# Altium schematic sheet [06] - BUZZER.SchDoc
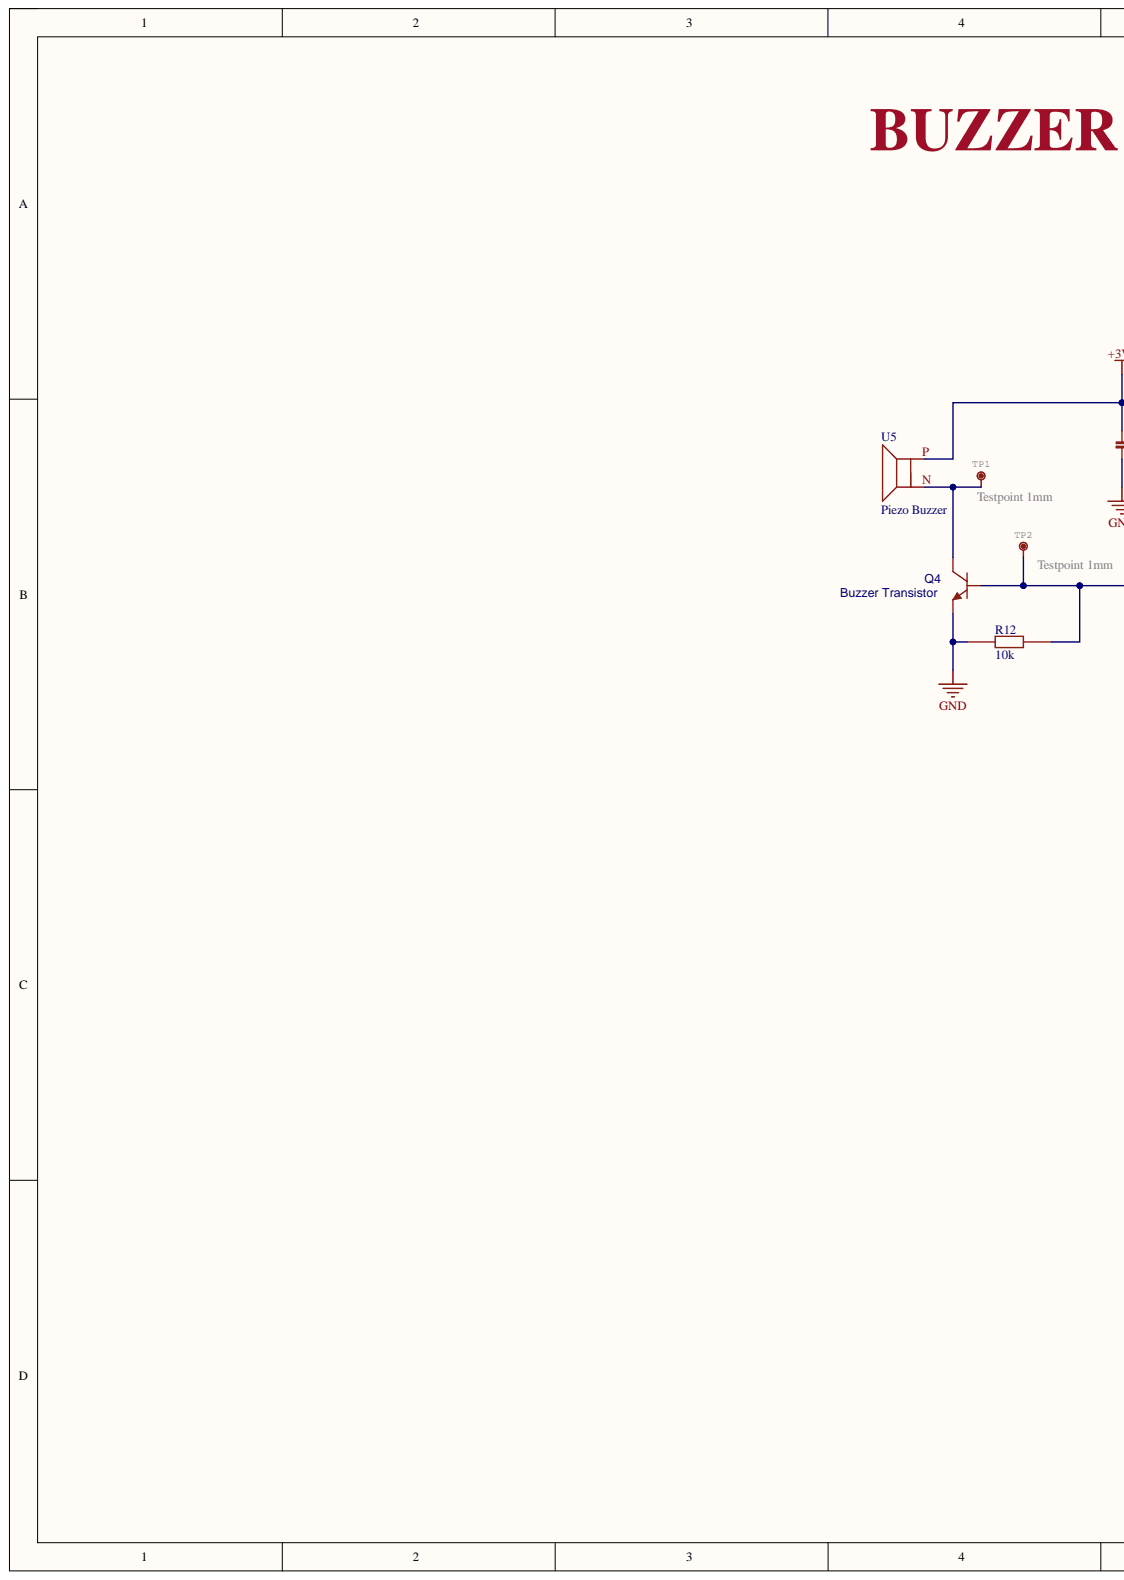
[diagram p0: [06] - BUZZER.SchDoc, left]
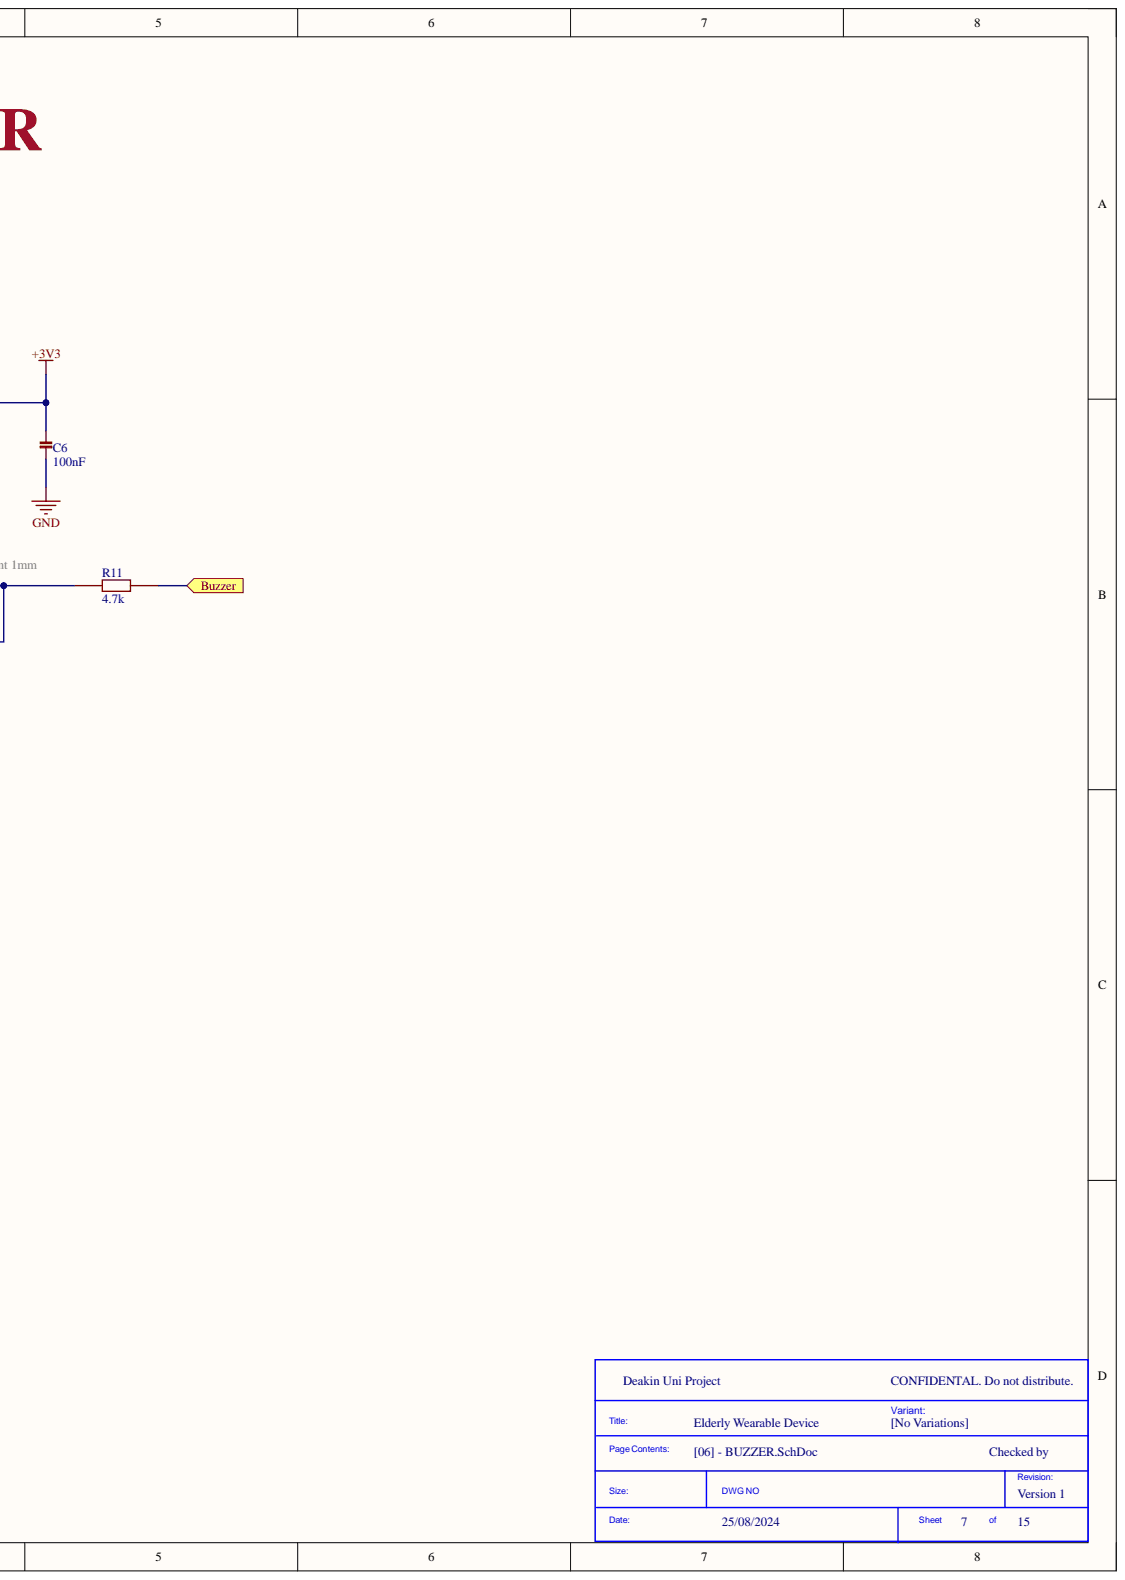
[diagram p1: [06] - BUZZER.SchDoc, right]

## Parts
C6  100nF  [C_0402]
Q4  Buzzer Transistor  [Buzzer_Transistor]
R11  4.7k  [R_0603]
R12  10k  [R_0603]
TP1  Testpoint 1mm  [Testpoint_1mm]
TP2  Testpoint 1mm  [Testpoint_1mm]
U5  Piezo Buzzer  [PIEZO_BUZZER]

## Ports
Buzzer

## Nets
+3V3: C6.2 U5.P
GND: C6.1 Q4.2 R12.2
Buzzer: R11.2 ; port Buzzer
NetQ4_1: Q4.1 R11.1 R12.1 TP2.X
NetQ4_3: Q4.3 TP1.X U5.N

## Library data of the parts
C6 = C_0402_100nF: SMD Capacitor; Manufacturer: Murata Electronics; Manufacturer Part Number: GRM155R71C104KA88J; ALL GOOD: OK_DONE; Component Kind: Standard; Package: 0402; Supplier: Digi-Key; Supplier Part Number: 490-6328-1-ND; Library Name: Elderly_Wearable_Device.SCHLIB
Q4 = Buzzer Transistor: Transistor, NPN, 40V, 0.2A, SOT-23; Manufacturer: onsemi; Manufacturer Part Number: MMBT3904LT1G; ALL GOOD: OK_DONE; Component Kind: Standard; Package: SOT-23-3; Supplier: Digi-Key; Supplier part number: MMBT3904LT1GOSCT-ND; Library Name: Elderly_Wearable_Device.SCHLIB
R11 = R_0603_4.7k: SMD Resistor; Manufacturer: Yageo; Manufacturer Part Number: RC0603FR-074K7L; ALL GOOD: OK_DONE; Component Kind: Standard; Package: 0603; Supplier: Digi-Key; Supplier Part Number: 311-4.70KHRCT-ND; Library Name: Elderly_Wearable_Device.SCHLIB
R12 = R_0603_10k: SMD Resistor; Manufacturer: Yageo; Manufacturer Part Number: RC0603FR-0710KL; ALL GOOD: OK_DONE; Component Kind: Standard; Package: 0603; Supplier: Digi-Key; Supplier Part Number: 311-10.0KHRCT-ND; Library Name: Elderly_Wearable_Device.SCHLIB
TP1 TP2 = Testpoint 1mm: MANUFACTURER: NA; ALL GOOD: OK_DONE; Component Kind: Standard; Description: Testpoint 1mm; Manufacturer Part Number: NA; Package: TP-1.00MM; Supplier: NA; Supplier Part Number: NA; Library Name: Elderly_Wearable_Device.SCHLIB
U5 = Piezo Buzzer: Buzzers Indicator, Internally Driven Piezo 3 V 30mA 3.2kHz 97dB @ 3V, 30cm Through Hole PC Pins; Manufacturer: Mallory Sonalert Products Inc.; Manufacturer Part Number: PK-11N40PQ; ALL GOOD: OK_DONE; Component Kind: Standard; Package: NA; Supplier: Digi-Key; Supplier Part Number: 458-1342-ND; Library Name: Elderly_Wearable_Device.SCHLIB
